# Altium schematic sheet WirelessIC.SchDoc(WirelessIC)
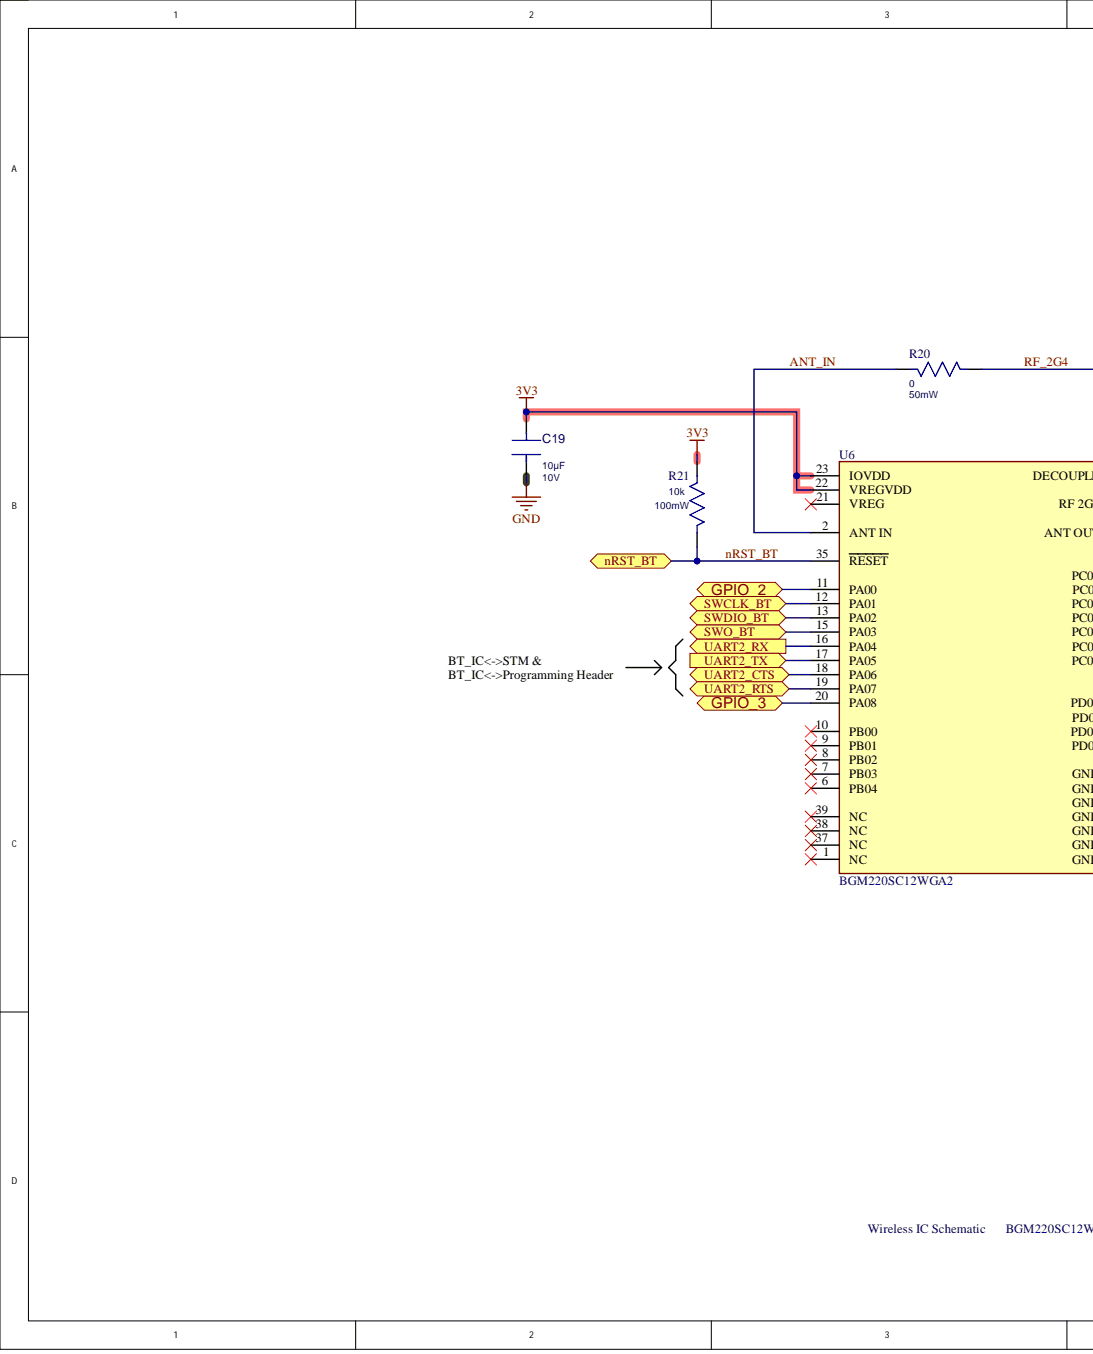
[diagram p0: WirelessIC.SchDoc, left]
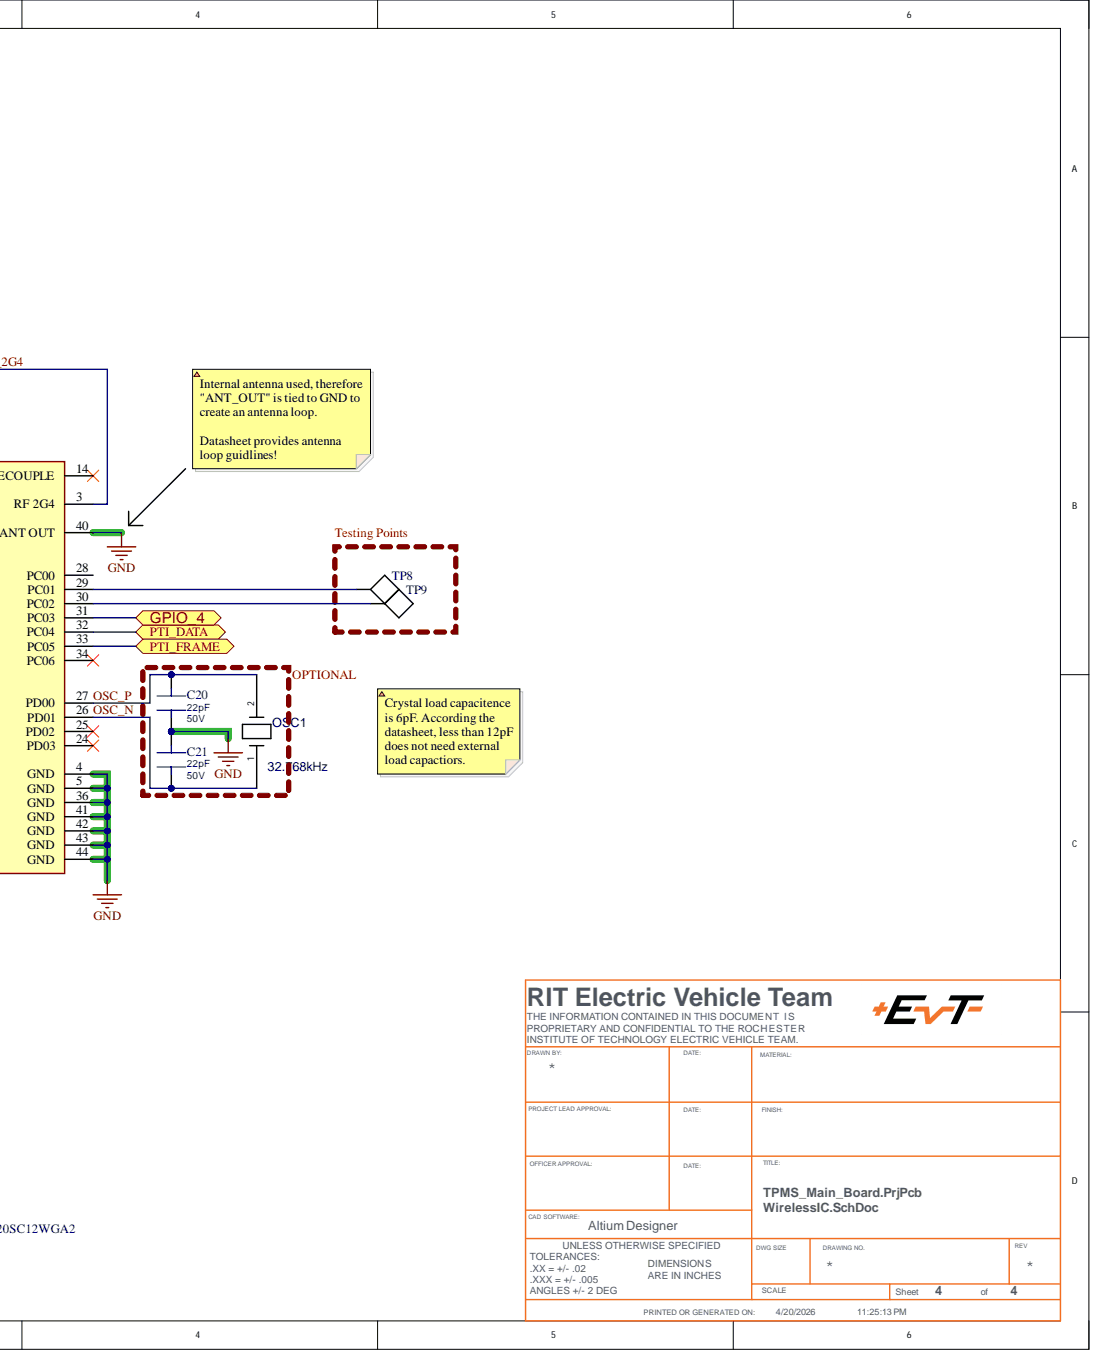
[diagram p1: WirelessIC.SchDoc, right]

## Parts
C19  Capacitance: 10µF  Voltage Rating: 10V  (hidden comment: CAP 10U0 10V X7R 10% 0603)
C20  Capacitance: 22pF  Voltage Rating: 50V  (hidden comment: CAP 22pF 50V C0G ±5% 0603)
C21  Capacitance: 22pF  Voltage Rating: 50V  (hidden comment: CAP 22pF 50V C0G ±5% 0603)
OSC1  Frequency: 32.768kHz  (hidden comment: NX3215SA-32.768K)
R20  Power Rating: 50mW  (hidden comment: RES 0 50mW 5%)
R21  Power Rating: 100mW  Resistance: 10k  (hidden comment: RES 10k 100m 0.1% 0603s)
TP8  50mil TP  (value not drawn)
TP9  50mil TP  (value not drawn)
U6  BGM220SC12WGA2

## Ports
GPIO_2, GPIO_3, GPIO_4, nRST_BT, PTI_DATA, PTI_FRAME, SWCLK_BT, SWDIO_BT, SWO_BT, UART2_CTS, UART2_RTS, UART2_RX, UART2_TX

## Nets
3V3: C19.1 R21.1 U6.22(VREGVDD) U6.23(IOVDD)
GND: C19.2 C20.2 C21.1 U6.4(GND) U6.5(GND) U6.36(GND) U6.40(ANT_OUT) U6.41(GND) U6.42(GND) U6.43(GND) U6.44(GND)
ANT_IN: R20.1 U6.2(ANT_IN)
GPIO_2: U6.11(PA00) ; port GPIO_2
GPIO_3: U6.20(PA08) ; port GPIO_3
GPIO_4: U6.31(PC03) ; port GPIO_4
nRST_BT: R21.2 U6.35(RESET) ; port nRST_BT
OSC_N: C21.2 OSC1.1 U6.26(PD01)
OSC_P: C20.1 OSC1.2 U6.27(PD00)
PTI_DATA: U6.32(PC04) ; port PTI_DATA
PTI_FRAME: U6.33(PC05) ; port PTI_FRAME
RF_2G4: R20.2 U6.3(RF_2G4)
SWCLK_BT: U6.12(PA01) ; port SWCLK_BT
SWDIO_BT: U6.13(PA02) ; port SWDIO_BT
SWO_BT: U6.15(PA03) ; port SWO_BT
UART2_CTS: U6.18(PA06) ; port UART2_CTS
UART2_RTS: U6.19(PA07) ; port UART2_RTS
UART2_RX: U6.16(PA04) ; port UART2_RX
UART2_TX: U6.17(PA05) ; port UART2_TX
NetTP8_1: TP8.1 U6.29(PC01)
NetTP9_1: TP9.1 U6.30(PC02)

Not connected: U6 1(NC) 6(PB04) 7(PB03) 8(PB02) 9(PB01) 10(PB00) 14(DECOUPLE) 21(VREG) 24(PD03) 25(PD02) 28(PC00) 34(PC06) 37(NC) 38(NC) 39(NC)

## Library data of the parts
C19 = CMP-00582-3: 10V 10uF X7R ±10% 0603 Multilayer Ceramic Capacitors MLCC - SMD/SMT ROHS; MPN: GRM188Z71A106KA73D; Polarized (Y/N): N; Component Kind: Standard; JLC Basic Part (Y/N): N; JLC Part #: C2959727; Package: 0603; Temperature Coefficient: X7R; Tolerance: 10%; Library Name: RIT-EVT-Vault
C20 C21 = CMP-00453-5: 22pF 50V C0G ±5% 0603 Multilayer Ceramic Capacitors MLCC - SMD/SMT ROHS; MPN: CL10C220JB8NNNC; Polarized (Y/N): N; Temperature Coefficient: +-30; Component Kind: Standard; JLC Basic Part (Y/N): Y; JLC Part #: C1653; Package: 0603; Tolerance: 5%; Library Name: RIT-EVT-Vault
OSC1 = CMP-00284-2: 32.768 kHz ±20ppm Crystal 12.5pF 70 kOhms 2-SMD, No Lead; ESR (Equivalent Series Resistance): 70kR; Load Capacitance: 6pF; Case/Package: SMD/SMT; Component Kind: Standard; Max Operating Temperature: 85°C; Min Operating Temperature: -40°C; Mount: Surface Mount; Packaging: Cut Tape; Ratings: AEC-Q200; Series: NX3215SA; Library Name: RIT-EVT-Vault
R20 = CMP-00676-1: -55℃~+125℃ 0Ω 25V 50mW Thick Film Resistor ±5% 0201 Chip Resistor - Surface Mount ROHS; MPN: CR0201JH0000G; Polarized (Y/N): N; Resistance: 0; Component Kind: Standard; JLC Basic Part (Y/N): Y; JLCPCB Part #: C100147; Package: 0201; Tolerance: 5%; Voltage Rating: 25V; Library Name: RIT-EVT-Vault
R21 = CMP-00540-2: -55℃~+155℃ 100mW 10kΩ 75V Thin Film Resistor ±0.1% ±25ppm/℃ 0603 Chip Resistor - Surface Mount ROHS; MPN: RT0603BRD0710KL; Polarized (Y/N): N; Component Kind: Standard; JLC Basic Part (Y/N): N; JLCPCB Part #: C95204; Package: 0603; Tolerance: 0.1%; Voltage Rating: 75V; Library Name: RIT-EVT-Vault
TP8 TP9 = CMP-00563-2: 50 mil TP; Component Kind: Standard; JLC BASIC Part (Y/N): N/A; JLCPCB Part #: N/A; MPN: N/A; Package: N/A; Library Name: RIT-EVT-Vault
U6 = CMP-00458-2: Bluetooth Module, Ble 5.2, 2Mbps Rohs Compliant: Yes; JLPCB Part #: C1019217; Component Kind: Standard; JLC Basic Part (Y/N): N; MPN: BGM220SC12WGA2; Package: -; Library Name: RIT-EVT-Vault
